# Altium schematic sheet P11_M1_RIBBON_CABLE.SchDoc(P11_M1_RIBBON_CABLE)
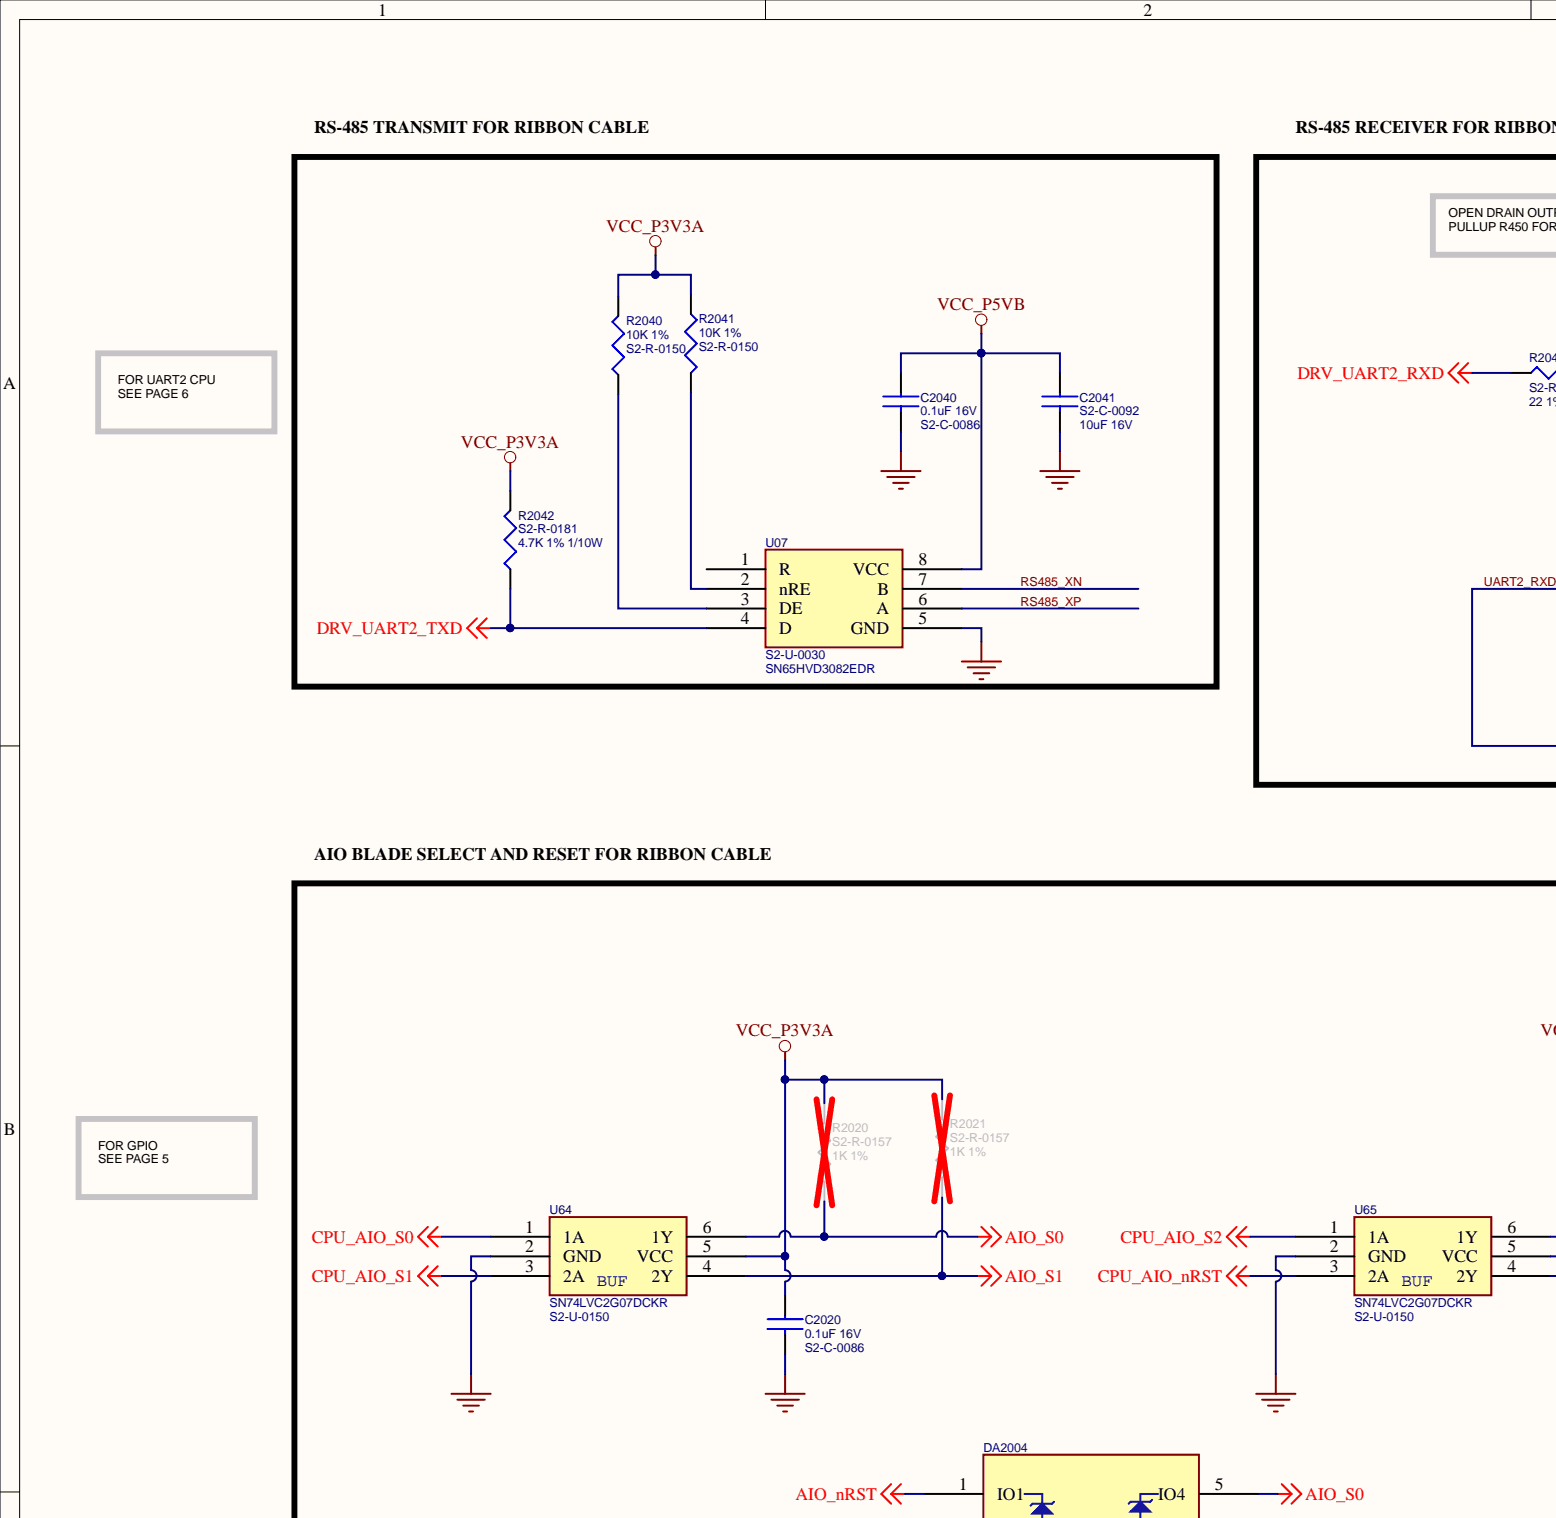
[diagram p0: P11_M1_RIBBON_CABLE.SchDoc, top left]
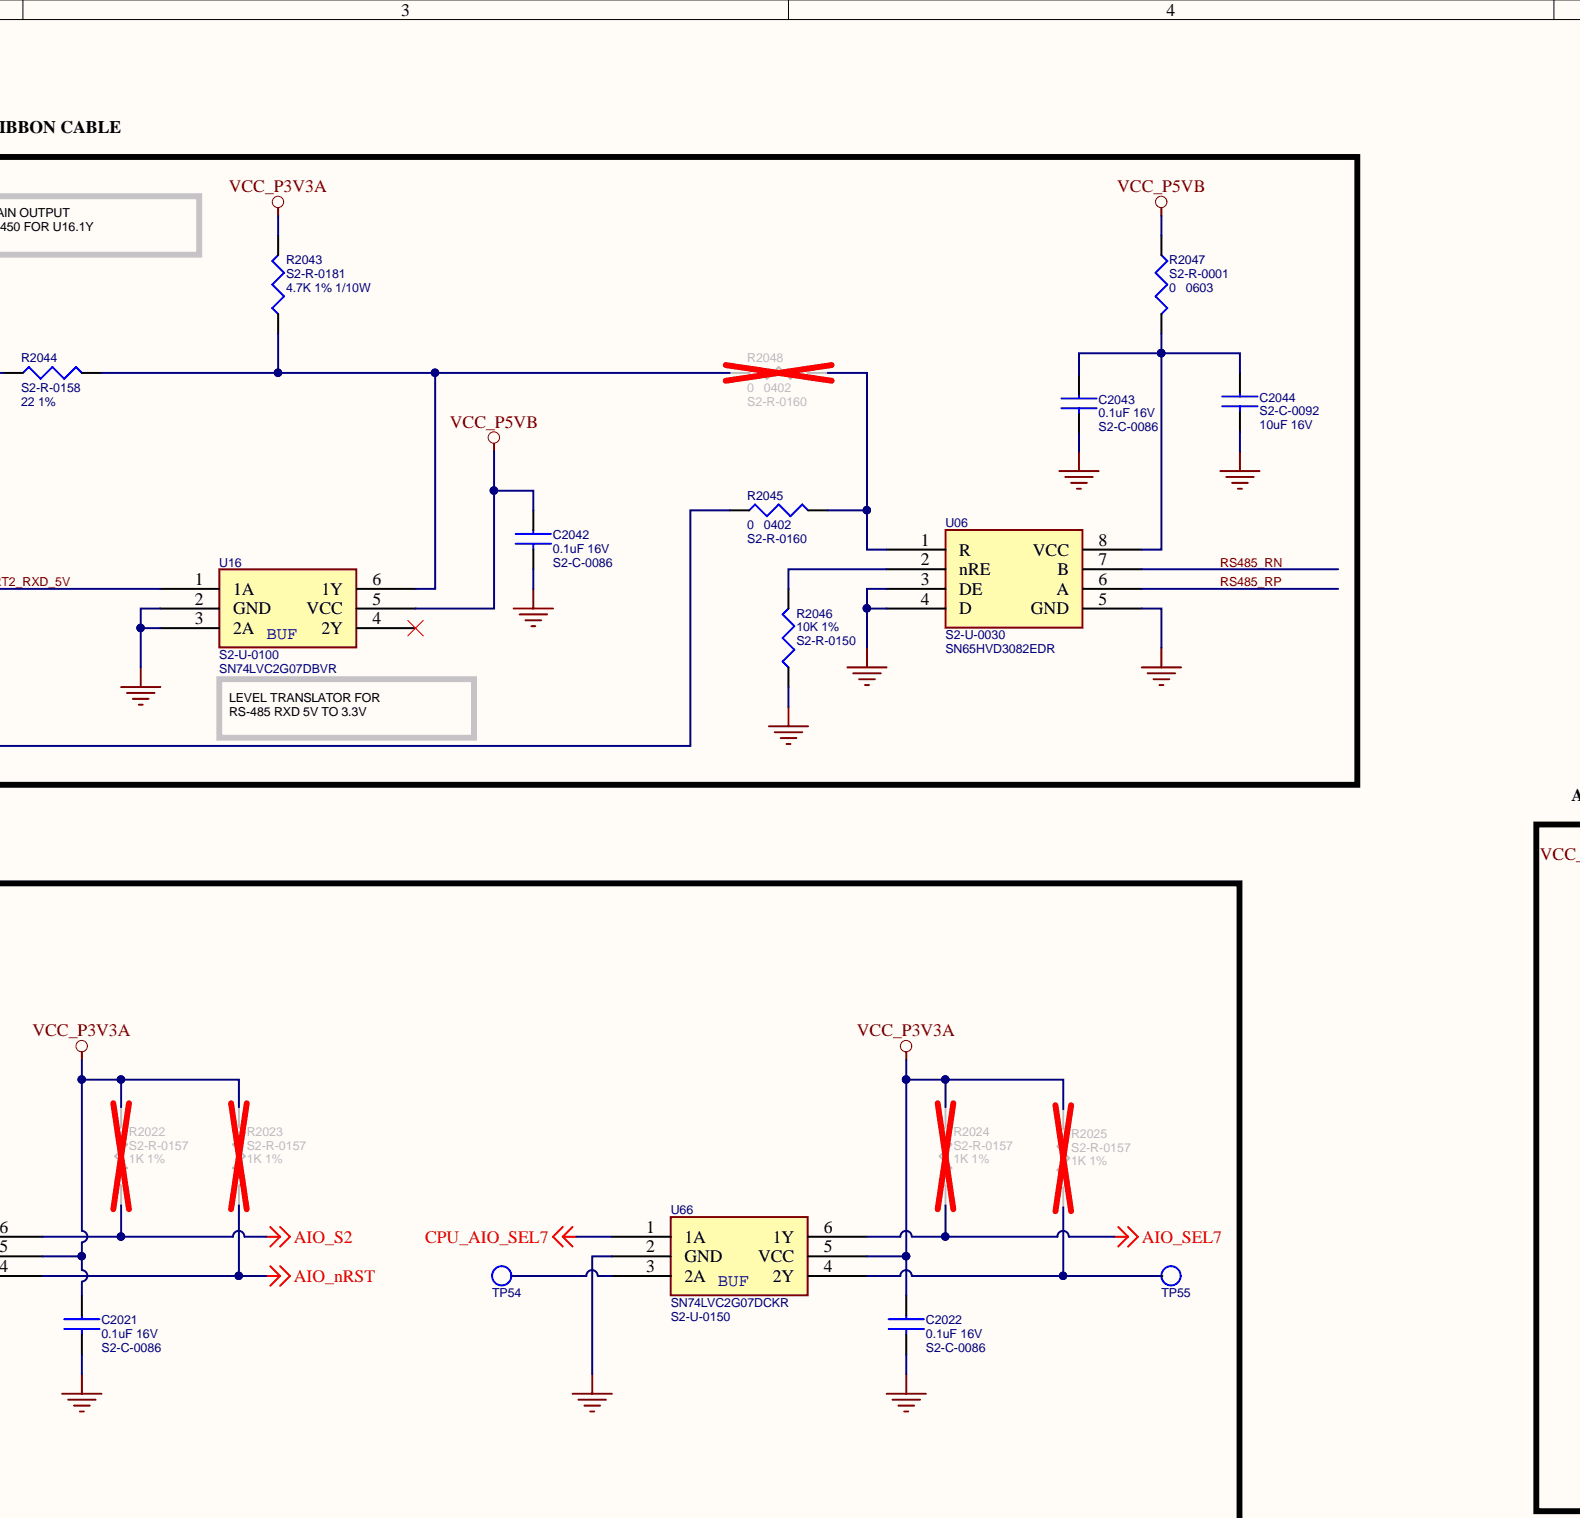
[diagram p1: P11_M1_RIBBON_CABLE.SchDoc, top centre]
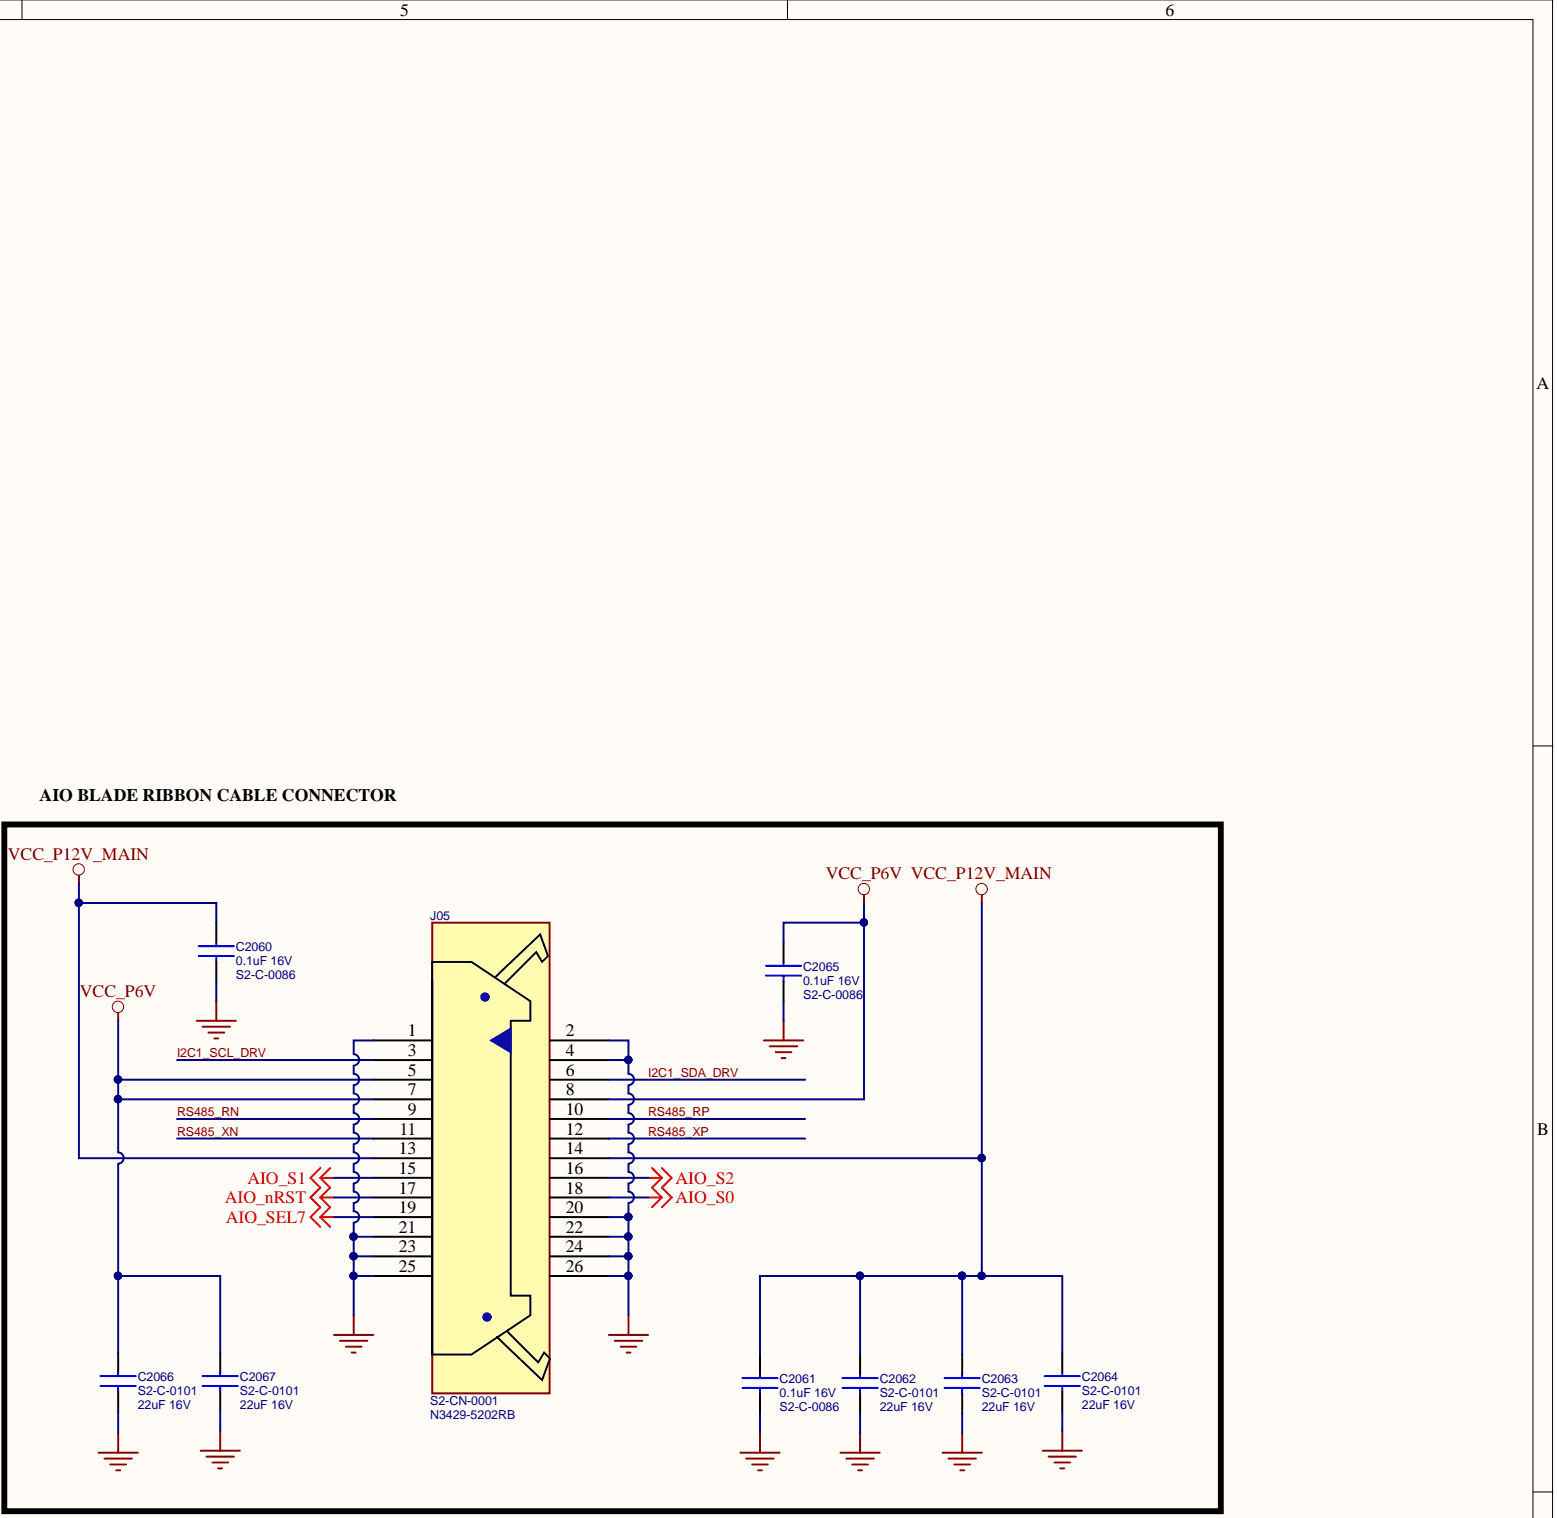
[diagram p2: P11_M1_RIBBON_CABLE.SchDoc, top right]
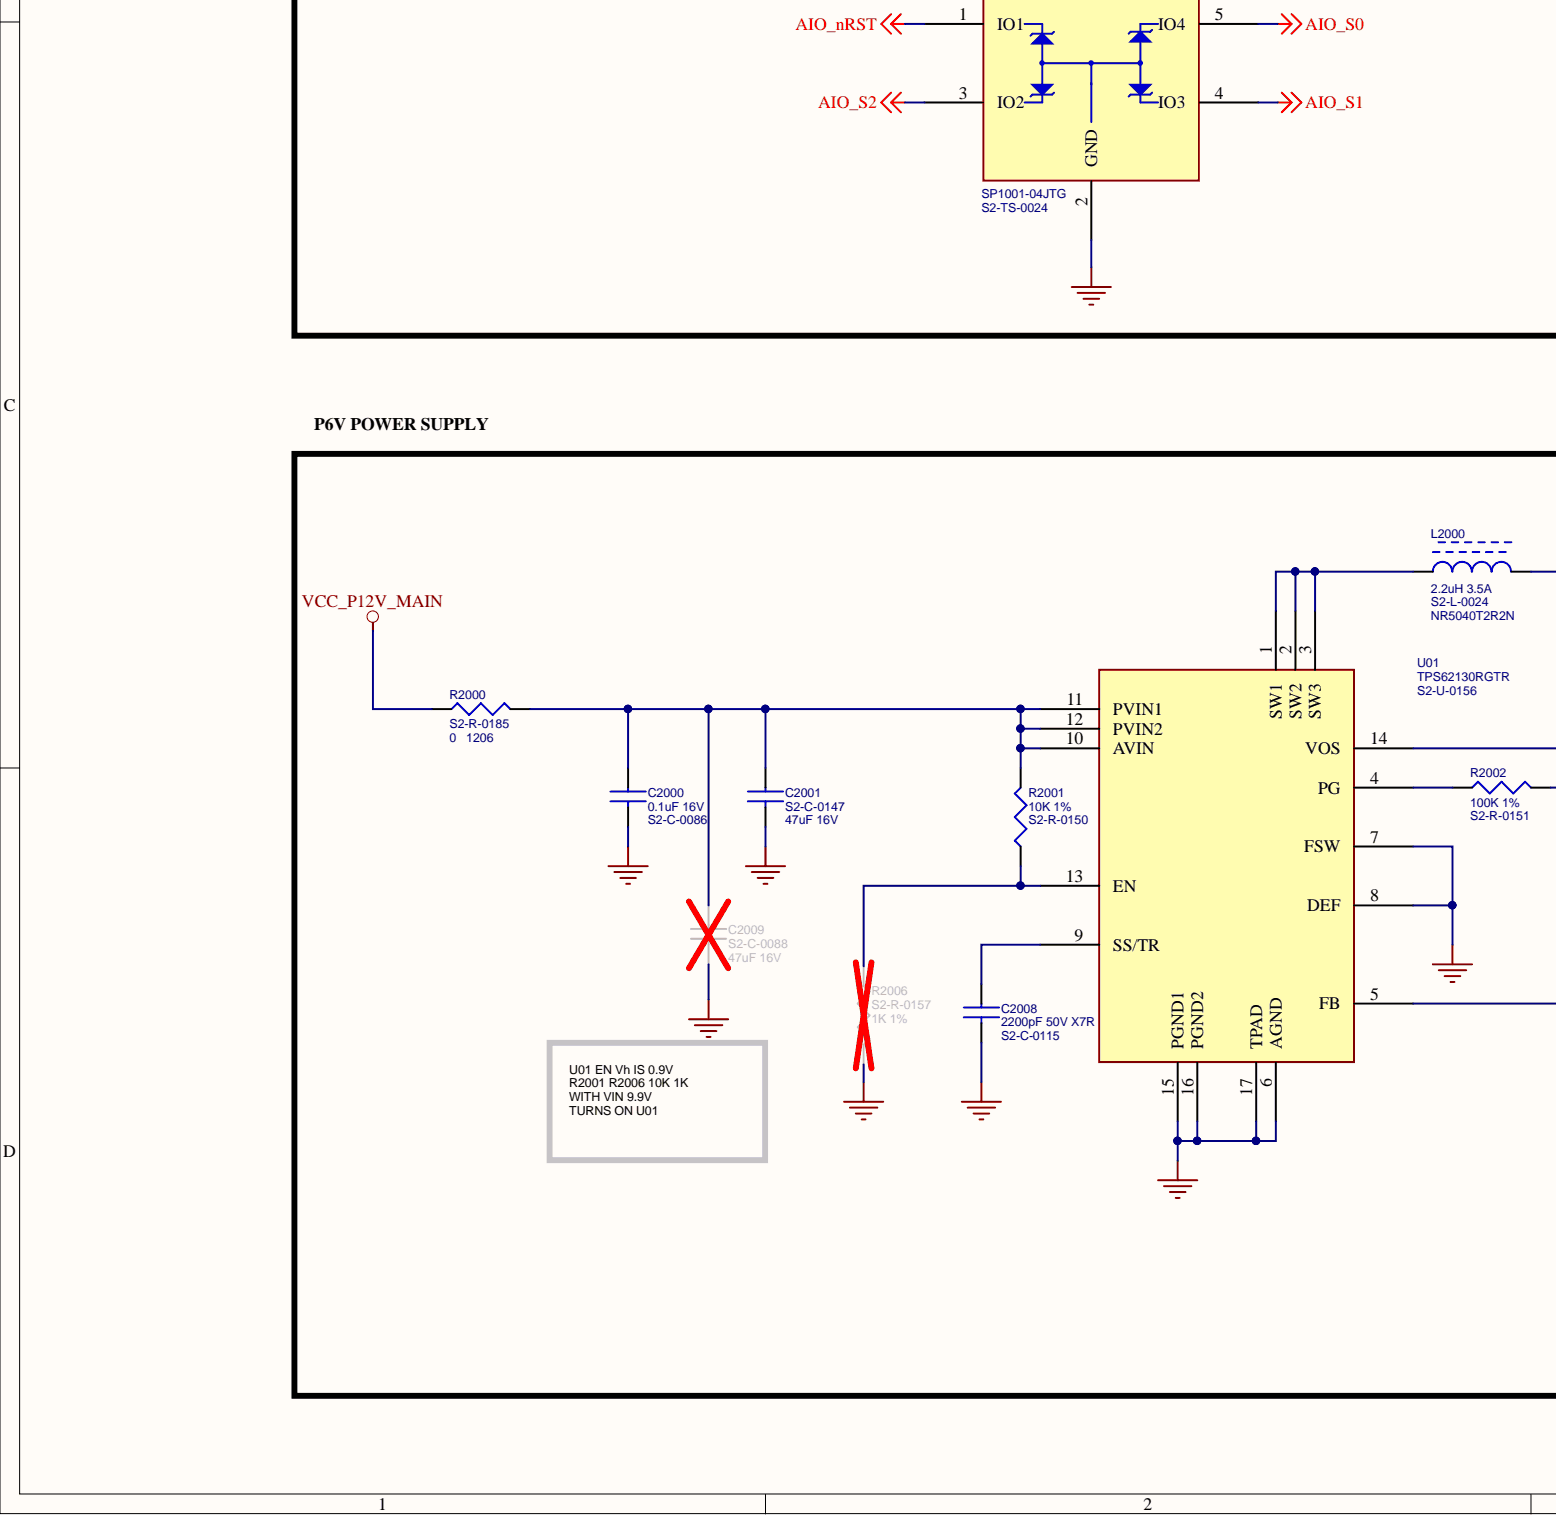
[diagram p3: P11_M1_RIBBON_CABLE.SchDoc, bottom left]
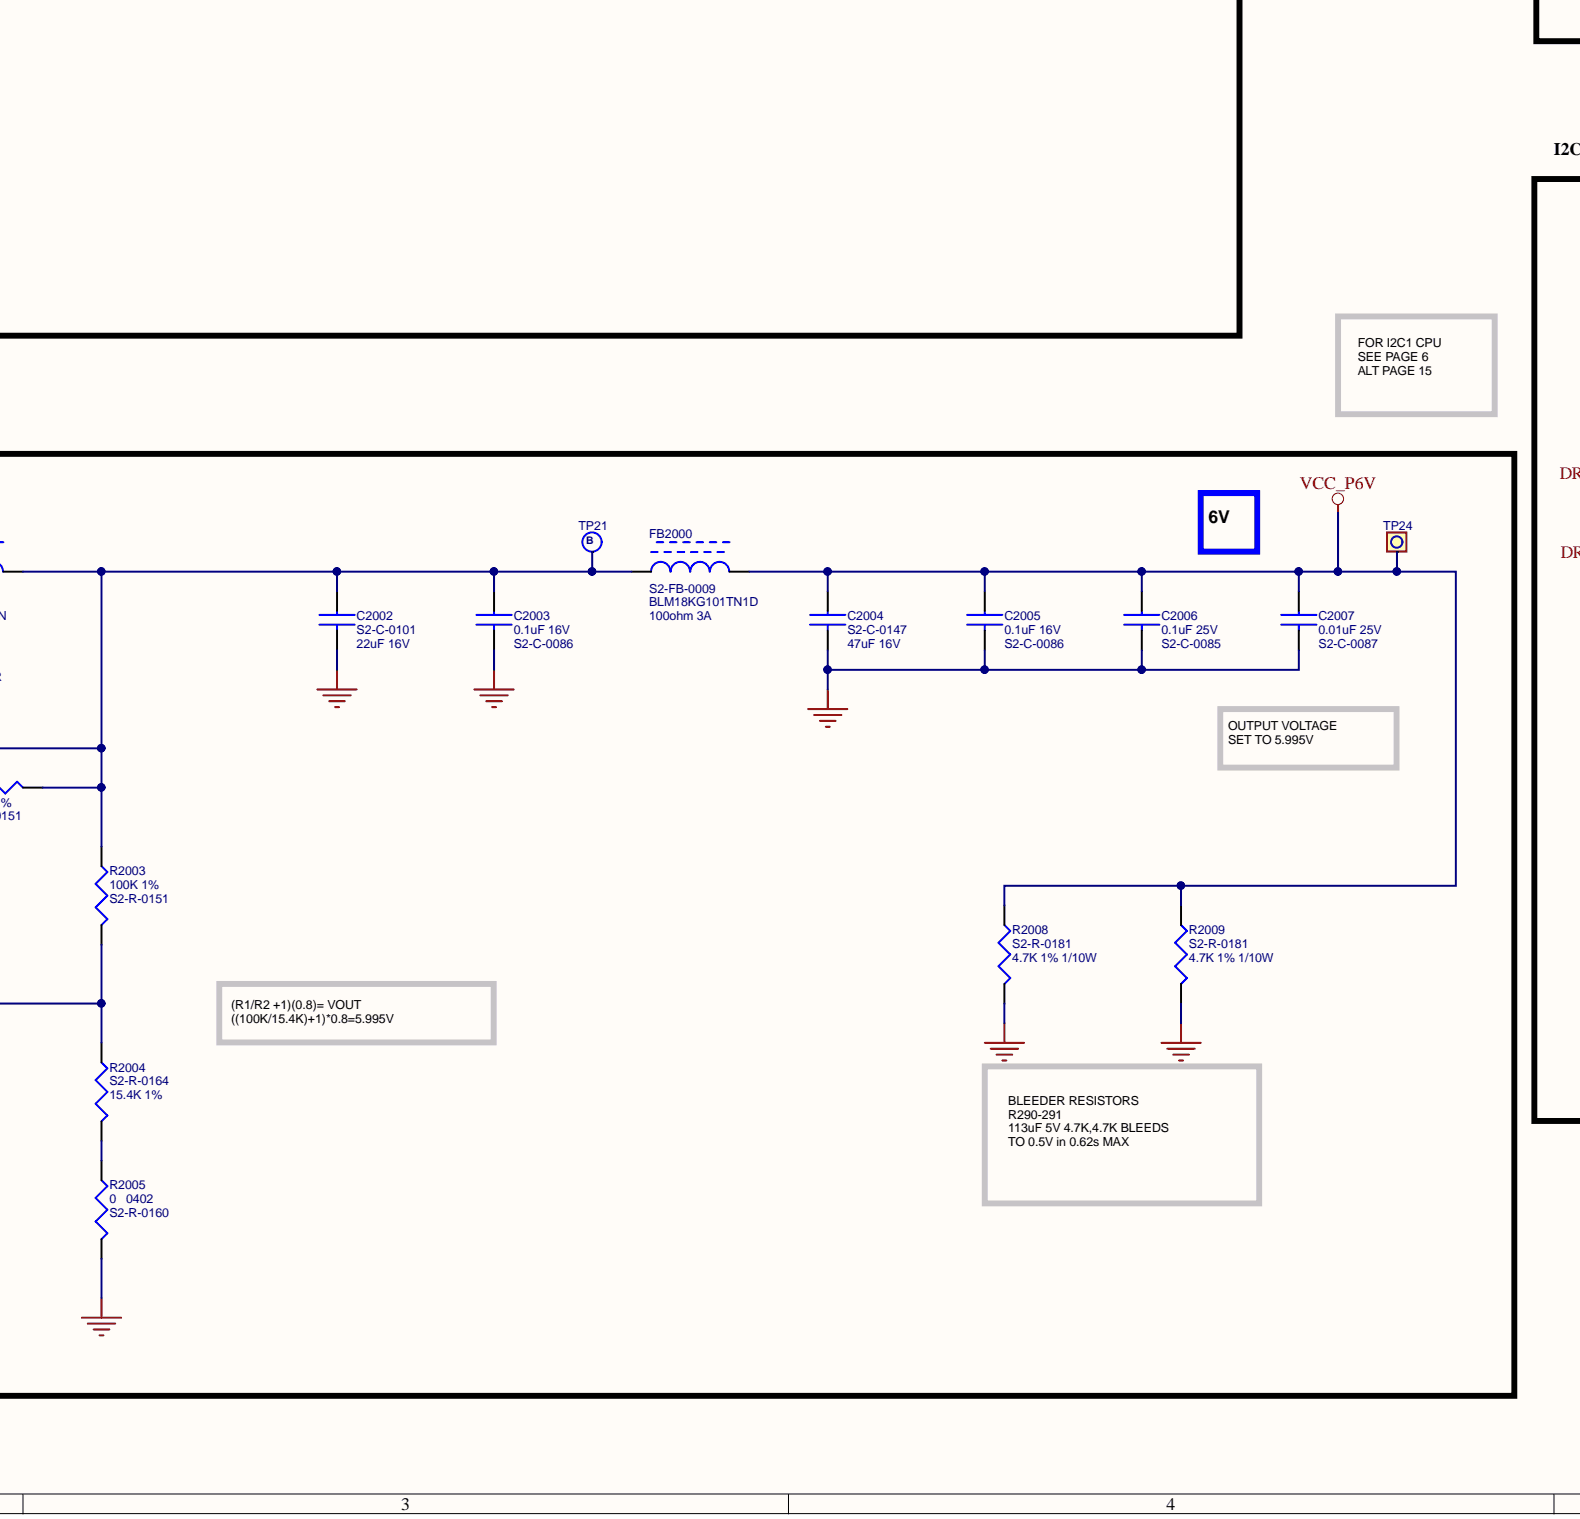
[diagram p4: P11_M1_RIBBON_CABLE.SchDoc, bottom centre]
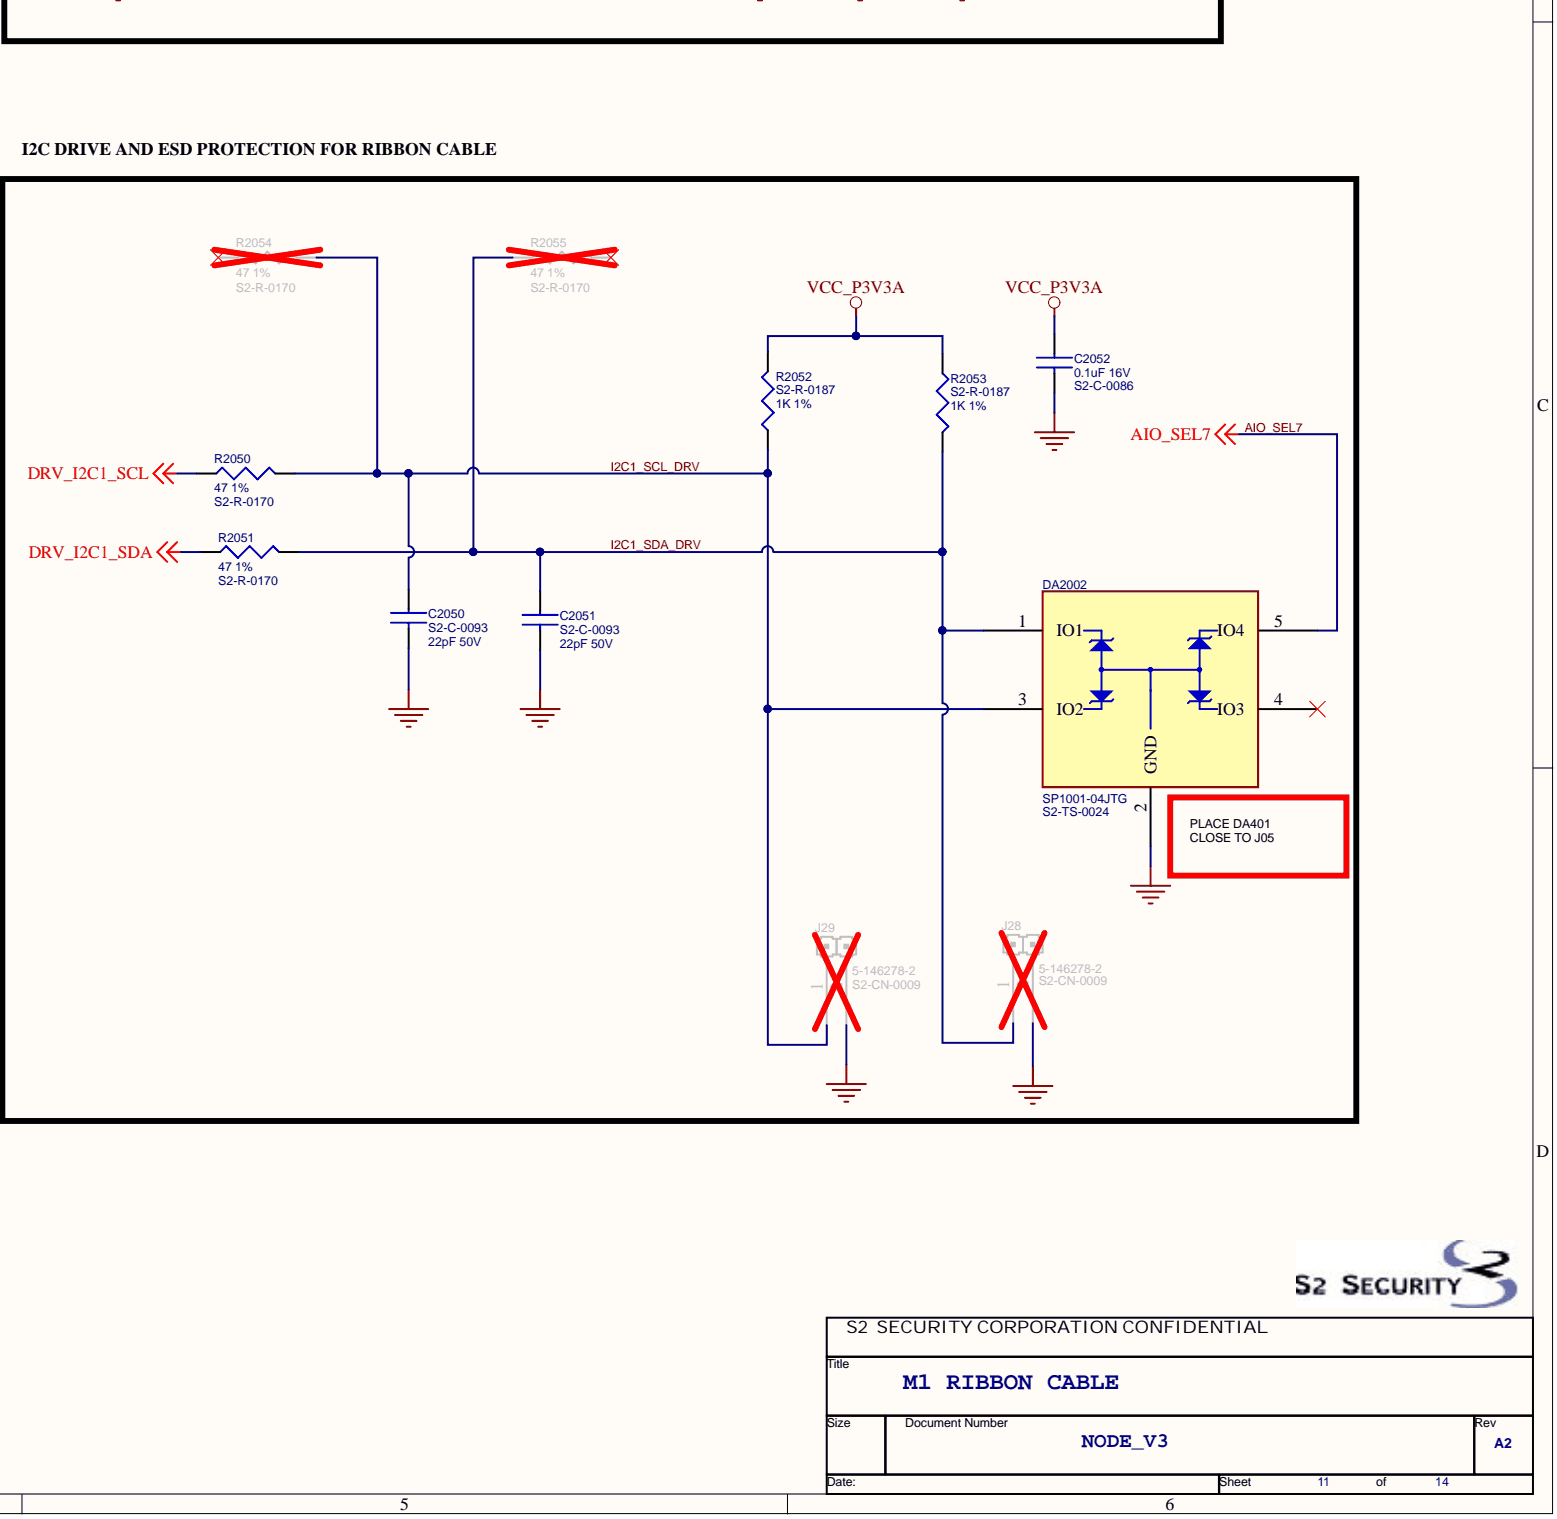
[diagram p5: P11_M1_RIBBON_CABLE.SchDoc, bottom right]

## Parts
C2000  S2_PN: S2-C-0086  DESC: 0.1uF 16V  [CAPC1005X55N]  (hidden comment: C_0U1_16V_0402)
C2001  S2_PN: S2-C-0147  DESC: 47uF 16V  [CAPC3216X180N]  (hidden comment: C_47U_16V_1206)
C2002  S2_PN: S2-C-0101  DESC: 22uF 16V  [CAPC2012X145N]  (hidden comment: C_22U_16V_0805)
C2003  S2_PN: S2-C-0086  DESC: 0.1uF 16V  [CAPC1005X55N]  (hidden comment: C_0U1_16V_0402)
C2004  S2_PN: S2-C-0147  DESC: 47uF 16V  [CAPC3216X180N]  (hidden comment: C_47U_16V_1206)
C2005  S2_PN: S2-C-0086  DESC: 0.1uF 16V  [CAPC1005X55N]  (hidden comment: C_0U1_16V_0402)
C2006  S2_PN: S2-C-0085  DESC: 0.1uF 25V  [CAPC1608X90N]  (hidden comment: C_0U1_25V_0603)
C2007  S2_PN: S2-C-0087  DESC: 0.01uF 25V  [CAPC1005X55N]  (hidden comment: C_0U01_25V_0402)
C2008  S2_PN: S2-C-0115  [CAPC1608X90N]  (hidden comment: C_2200P_50V_0603_X7R)
C2009  S2_PN: S2-C-0088  DESC: 47uF 16V  [CAPC3225X270N]  (hidden comment: C_47U_16V_1210)
C2020  S2_PN: S2-C-0086  DESC: 0.1uF 16V  [CAPC1005X55N]  (hidden comment: C_0U1_16V_0402)
C2021  S2_PN: S2-C-0086  DESC: 0.1uF 16V  [CAPC1005X55N]  (hidden comment: C_0U1_16V_0402)
C2022  S2_PN: S2-C-0086  DESC: 0.1uF 16V  [CAPC1005X55N]  (hidden comment: C_0U1_16V_0402)
C2040  S2_PN: S2-C-0086  DESC: 0.1uF 16V  [CAPC1005X55N]  (hidden comment: C_0U1_16V_0402)
C2041  S2_PN: S2-C-0092  DESC: 10uF 16V  [CAPC2012X145N]  (hidden comment: C_10U_16V_0805)
C2042  S2_PN: S2-C-0086  DESC: 0.1uF 16V  [CAPC1005X55N]  (hidden comment: C_0U1_16V_0402)
C2043  S2_PN: S2-C-0086  DESC: 0.1uF 16V  [CAPC1005X55N]  (hidden comment: C_0U1_16V_0402)
C2044  S2_PN: S2-C-0092  DESC: 10uF 16V  [CAPC2012X145N]  (hidden comment: C_10U_16V_0805)
C2050  S2_PN: S2-C-0093  DESC: 22pF 50V  [CAPC1005X55N]  (hidden comment: C_22P_50V_0402_C0G)
C2051  S2_PN: S2-C-0093  DESC: 22pF 50V  [CAPC1005X55N]  (hidden comment: C_22P_50V_0402_C0G)
C2052  S2_PN: S2-C-0086  DESC: 0.1uF 16V  [CAPC1005X55N]  (hidden comment: C_0U1_16V_0402)
C2060  S2_PN: S2-C-0086  DESC: 0.1uF 16V  [CAPC1005X55N]  (hidden comment: C_0U1_16V_0402)
C2061  S2_PN: S2-C-0086  DESC: 0.1uF 16V  [CAPC1005X55N]  (hidden comment: C_0U1_16V_0402)
C2062  S2_PN: S2-C-0101  DESC: 22uF 16V  [CAPC2012X145N]  (hidden comment: C_22U_16V_0805)
C2063  S2_PN: S2-C-0101  DESC: 22uF 16V  [CAPC2012X145N]  (hidden comment: C_22U_16V_0805)
C2064  S2_PN: S2-C-0101  DESC: 22uF 16V  [CAPC2012X145N]  (hidden comment: C_22U_16V_0805)
C2065  S2_PN: S2-C-0086  DESC: 0.1uF 16V  [CAPC1005X55N]  (hidden comment: C_0U1_16V_0402)
C2066  S2_PN: S2-C-0101  DESC: 22uF 16V  [CAPC2012X145N]  (hidden comment: C_22U_16V_0805)
C2067  S2_PN: S2-C-0101  DESC: 22uF 16V  [CAPC2012X145N]  (hidden comment: C_22U_16V_0805)
DA2002  S2_PN: S2-TS-0024  DESC: SP1001-04JTG  [SOIC65Px210X110P-5N]  (hidden comment: DA_SP1001-04JTG_ESD_QUAD)
DA2004  S2_PN: S2-TS-0024  DESC: SP1001-04JTG  [SOIC65Px210X110P-5N]  (hidden comment: DA_SP1001-04JTG_ESD_QUAD)
FB2000  S2_PN: S2-FB-0009  DESC: BLM18KG101TN1D  ATTR: 100ohm 3A  [FB0603]  (hidden comment: FB_3A_100OHM_0603_DC_30mOHM)
J05  S2_PN: S2-CN-0001  DESC: N3429-5202RB  [CONN_N3429-5202RB]  (hidden comment: CN_100_26POS_13x2_RTA_LATCH)
J28  S2_PN: S2-CN-0009  PKG: 5-146278-2  [Conn_5-146278-2]  (hidden comment: CN_100_2POS_STR_UNSHR)
J29  S2_PN: S2-CN-0009  PKG: 5-146278-2  [Conn_5-146278-2]  (hidden comment: CN_100_2POS_STR_UNSHR)
L2000  S2_PN: S2-L-0024  ATTR2: 2.2uH 3.5A  [IND_NR5040T2R2N]  (hidden comment: L_2U2_3A5_NR5040T2R2N)
R2000  S2_PN: S2-R-0185  DESC: 0   1206  [RESC3216X60N]  (hidden comment: R_0_1206)
R2001  S2_PN: S2-R-0150  DESC: 10K 1%  [RESC1005X40N]  (hidden comment: R_10K_0402)
R2002  S2_PN: S2-R-0151  DESC: 100K 1%  [RESC1005X40N]  (hidden comment: R_100K_0402)
R2003  S2_PN: S2-R-0151  DESC: 100K 1%  [RESC1005X40N]  (hidden comment: R_100K_0402)
R2004  S2_PN: S2-R-0164  DESC: 15.4K 1%  [RESC1005X40N]  (hidden comment: R_15K4_0402)
R2005  S2_PN: S2-R-0160  DESC: 0   0402  [RESC1005X40N]  (hidden comment: R_0_0402)
R2006  S2_PN: S2-R-0157  DESC: 1K 1%  [RESC1005X40N]  (hidden comment: R_1K_0402)
R2008  S2_PN: S2-R-0181  DESC: 4.7K 1% 1/10W  [RESC1608X55N]  (hidden comment: R_4K7_0603)
R2009  S2_PN: S2-R-0181  DESC: 4.7K 1% 1/10W  [RESC1608X55N]  (hidden comment: R_4K7_0603)
R2020  S2_PN: S2-R-0157  DESC: 1K 1%  [RESC1005X40N]  (hidden comment: R_1K_0402)
R2021  S2_PN: S2-R-0157  DESC: 1K 1%  [RESC1005X40N]  (hidden comment: R_1K_0402)
R2022  S2_PN: S2-R-0157  DESC: 1K 1%  [RESC1005X40N]  (hidden comment: R_1K_0402)
R2023  S2_PN: S2-R-0157  DESC: 1K 1%  [RESC1005X40N]  (hidden comment: R_1K_0402)
R2024  S2_PN: S2-R-0157  DESC: 1K 1%  [RESC1005X40N]  (hidden comment: R_1K_0402)
R2025  S2_PN: S2-R-0157  DESC: 1K 1%  [RESC1005X40N]  (hidden comment: R_1K_0402)
R2040  S2_PN: S2-R-0150  DESC: 10K 1%  [RESC1005X40N]  (hidden comment: R_10K_0402)
R2041  S2_PN: S2-R-0150  DESC: 10K 1%  [RESC1005X40N]  (hidden comment: R_10K_0402)
R2042  S2_PN: S2-R-0181  DESC: 4.7K 1% 1/10W  [RESC1608X55N]  (hidden comment: R_4K7_0603)
R2043  S2_PN: S2-R-0181  DESC: 4.7K 1% 1/10W  [RESC1608X55N]  (hidden comment: R_4K7_0603)
R2044  S2_PN: S2-R-0158  DESC: 22 1%  [RESC1005X40N]  (hidden comment: R_22_0402)
R2045  S2_PN: S2-R-0160  DESC: 0   0402  [RESC1005X40N]  (hidden comment: R_0_0402)
R2046  S2_PN: S2-R-0150  DESC: 10K 1%  [RESC1005X40N]  (hidden comment: R_10K_0402)
R2047  S2_PN: S2-R-0001  DESC: 0   0603  [RESC1608X55N]  (hidden comment: R_0_0603)
R2048  S2_PN: S2-R-0160  DESC: 0   0402  [RESC1005X40N]  (hidden comment: R_0_0402)
R2050  S2_PN: S2-R-0170  DESC: 47 1%  [RESC1005X40N]  (hidden comment: R_47_0402)
R2051  S2_PN: S2-R-0170  DESC: 47 1%  [RESC1005X40N]  (hidden comment: R_47_0402)
R2052  S2_PN: S2-R-0187  DESC: 1K 1%  [RESC1608X55N]  (hidden comment: R_1K_0603)
R2053  S2_PN: S2-R-0187  DESC: 1K 1%  [RESC1608X55N]  (hidden comment: R_1K_0603)
R2054  S2_PN: S2-R-0170  DESC: 47 1%  [RESC1005X40N]  (hidden comment: R_47_0402)
R2055  S2_PN: S2-R-0170  DESC: 47 1%  [RESC1005X40N]  (hidden comment: R_47_0402)
TP21  TP_SMT_TEST_POINT_BOTTOM  [TP35_SMT]  (value not drawn)
TP24  TP_TH_TEST_POINT_SQUARE  [TEST-PT-TH-SQ]  (value not drawn)
TP54  TP_TH_TEST_POINT  [TEST-PT-TH]  (value not drawn)
TP55  TP_TH_TEST_POINT  [TEST-PT-TH]  (value not drawn)
U01  S2_PN: S2-U-0156  DESC: TPS62130RGTR  [QFN050PX310x100-16N]  (hidden comment: U_TPS62130RGTR_BUCK_17V_3A)
U06  S2_PN: S2-U-0030  DESC: SN65HVD3082EDR  [SOIC127P600X175-8N]  (hidden comment: U_RS485_HALF_DUPLEX)
U07  S2_PN: S2-U-0030  DESC: SN65HVD3082EDR  [SOIC127P600X175-8N]  (hidden comment: U_RS485_HALF_DUPLEX)
U16  S2_PN: S2-U-0100  DESC: SN74LVC2G07DBVR  [SOT95P280X145-6N]  (hidden comment: U_SN74LVC2G07DBVR_DUAL_BUFFER)
U64  S2_PN: S2-U-0150  DESC: SN74LVC2G07DCKR  [SOIC65Px210X110P-6N]  (hidden comment: U_SN74LVC2G07DCKR_DUAL_BUFFER)
U65  S2_PN: S2-U-0150  DESC: SN74LVC2G07DCKR  [SOIC65Px210X110P-6N]  (hidden comment: U_SN74LVC2G07DCKR_DUAL_BUFFER)
U66  S2_PN: S2-U-0150  DESC: SN74LVC2G07DCKR  [SOIC65Px210X110P-6N]  (hidden comment: U_SN74LVC2G07DCKR_DUAL_BUFFER)

## Nets
AIO_nRST: DA2004.1(IO1) J05.17 R2023.2 U65.4(2Y)
AIO_S0: DA2004.5(IO4) J05.18 R2020.2 U64.6(1Y)
AIO_S1: DA2004.4(IO3) J05.15 R2021.2 U64.4(2Y)
AIO_S2: DA2004.3(IO2) J05.16 R2022.2 U65.6(1Y)
CPU_AIO_nRST: U65.3(2A)
CPU_AIO_S0: U64.1(1A)
CPU_AIO_S1: U64.3(2A)
CPU_AIO_S2: U65.1(1A)
CPU_AIO_SEL7: U66.1(1A)
DRV_I2C1_SCL: R2050.1
DRV_I2C1_SDA: R2051.1
DRV_UART2_RXD: R2044.1
DRV_UART2_TXD: R2042.2 U07.4(D)
GND: C2000.2 C2001.2 C2002.2 C2003.2 C2004.2 C2005.2 C2006.2 C2007.2 C2008.2 C2009.2 C2020.2 C2021.2 C2022.2 C2040.2 C2041.2 C2042.2 C2043.2 C2044.2 C2050.2 C2051.2 C2052.2 C2060.2 C2061.2 C2062.2 C2063.2 C2064.2 C2065.2 C2066.2 C2067.2 DA2002.2(GND) DA2004.2(GND) J05.1 J05.2 J05.4 J05.20 J05.21 J05.22 J05.23 J05.24 J05.25 J05.26 J28.2 J29.2 R2005.2 R2006.2 R2008.2 R2009.2 R2046.2 U01.6(AGND) U01.7(FSW) U01.8(DEF) U01.15(PGND1) U01.16(PGND2) U01.17(TPAD) U06.3(DE) U06.4(D) U06.5(GND) U07.5(GND) U16.2(GND) U16.3(2A) U64.2(GND) U65.2(GND) U66.2(GND)
VCC_P3V3A: C2020.1 C2021.1 C2022.1 C2052.1 R2020.1 R2021.1 R2022.1 R2023.1 R2024.1 R2025.1 R2040.1 R2041.1 R2042.1 R2043.1 R2052.1 R2053.1 U64.5(VCC) U65.5(VCC) U66.5(VCC)
VCC_P5VB: C2040.1 C2041.1 C2042.1 R2047.1 U07.8(VCC) U16.5(VCC)
VCC_P6V: C2004.1 C2005.1 C2006.1 C2007.1 C2065.1 C2066.1 C2067.1 FB2000.2 J05.5 J05.7 J05.8 R2008.1 R2009.1 TP24.1
VCC_P12V_MAIN: C2060.1 C2061.1 C2062.1 C2063.1 C2064.1 J05.13 J05.14 R2000.1
AIO_SEL7: DA2002.5(IO4) J05.19 R2024.2 U66.6(1Y)
I2C1_SCL_DRV: C2050.1 DA2002.3(IO2) J05.3 J29.1 R2050.2 R2052.2 R2054.2
I2C1_SDA_DRV: C2051.1 DA2002.1(IO1) J05.6 J28.1 R2051.2 R2053.2 R2055.1
RS485_RN: J05.9 U06.7(B)
RS485_RP: J05.10 U06.6(A)
RS485_XN: J05.11 U07.7(B)
RS485_XP: J05.12 U07.6(A)
UART2_RXD_5V: R2045.1 U16.1(1A)
NetC2000_1: C2000.1 C2001.1 C2009.1 R2000.2 R2001.1 U01.10(AVIN) U01.11(PVIN1) U01.12(PVIN2)
NetC2002_1: C2002.1 C2003.1 FB2000.1 L2000.2 R2002.2 R2003.1 TP21.1 U01.14(VOS)
NetC2008_1: C2008.1 U01.9(SS/TR)
NetC2043_1: C2043.1 C2044.1 R2047.2 U06.8(VCC)
NetL2000_1: L2000.1 U01.1(SW1) U01.2(SW2) U01.3(SW3)
NetR2001_2: R2001.2 R2006.1 U01.13(EN)
NetR2002_1: R2002.1 U01.4(PG)
NetR2003_2: R2003.2 R2004.1 U01.5(FB)
NetR2004_2: R2004.2 R2005.1
NetR2025_2: R2025.2 TP55.1 U66.4(2Y)
NetR2040_2: R2040.2 U07.3(DE)
NetR2041_2: R2041.2 U07.2(nRE)
NetR2043_2: R2043.2 R2044.2 R2048.1 U16.6(1Y)
NetR2045_2: R2045.2 R2048.2 U06.1(R)
NetR2046_1: R2046.1 U06.2(nRE)
NetTP54_1: TP54.1 U66.3(2A)

Not connected: DA2002 4(IO3); R2054 1; R2055 2; U07 1(R); U16 4(2Y)

## Library data of the parts
C2000 C2003 C2005 C2020-C2022 C2040 C2042 C2043 C2052 C2060 C2061 C2065 = C_0U1_16V_0402: PKG: CC0402IPC; ATTR: X7R 10%; Library Name: NODE_V2_M1.SCHLIB; Component Kind: Standard
C2001 C2004 = C_47U_16V_1206: PKG: CC1206IPC; ATTR: X5R 20%; Library Name: NODE_V2_M1.SCHLIB; Component Kind: Standard
C2002 C2062-C2064 C2066 C2067 = C_22U_16V_0805: PKG: CC0805IPC; ATTR: X5R 20%; Library Name: NODE_V2_M1.SCHLIB; Component Kind: Standard
C2006 = C_0U1_25V_0603: PKG: CC0603IPC; ATTR: X7R 10%; Library Name: NODE_V2_M1.SCHLIB; Component Kind: Standard
C2007 = C_0U01_25V_0402: PKG: CC0402IPC; ATTR: X7R 10%; Library Name: NODE_V2_M1.SCHLIB; Component Kind: Standard
C2008 = C_2200P_50V_0603_X7R: PKG: CC0603IPC; DESC: 2200pF 50V X7R; ATTR: X7R 10%; Library Name: NODE_V2_M1.SCHLIB; Component Kind: Standard
C2009 = C_47U_16V_1210: PKG: CC1210IPC; ATTR: X5R 20%; Library Name: NODE_V2_M1.SCHLIB; Component Kind: Standard
C2041 C2044 = C_10U_16V_0805: PKG: CC0805IPC; ATTR: X5R 10%; Library Name: NODE_V2_M1.SCHLIB; Component Kind: Standard
C2050 C2051 = C_22P_50V_0402_C0G: PKG: CC0402IPC; ATTR: C0G 5%; Library Name: NODE_V2_M1.SCHLIB; Component Kind: Standard
DA2002 DA2004 = DA_SP1001-04JTG_ESD_QUAD: PKG: SC-70-5; ATTR: TVS, UNI_DIR SP1001, 4 Channel, 8pF, 15kV  Array; Library Name: NODE_V2_M1.SCHLIB; Component Kind: Standard
FB2000 = FB_3A_100OHM_0603_DC_30mOHM: PKG: CC0603IPC; Library Name: NODE_V2_M1.SCHLIB; Component Kind: Standard
J05 = CN_100_26POS_13x2_RTA_LATCH: PKG: 2M54_13x2_TH_LATCH; ATTR: CONN HEADER LATCH/EJEC  RTA DUAL ROW 26POS; Library Name: NODE_V2_M1.SCHLIB; Component Kind: Standard
J28 J29 = CN_100_2POS_STR_UNSHR: ATTR: HEADER MALE 2POS 0.100" STRAIGHT UNSHROUDED; Library Name: NODE_V2_M1.SCHLIB; DESC: 5-146278-2; Component Kind: Standard
L2000 = L_2U2_3A5_NR5040T2R2N: PKG: NR5040; ATTR: INDUCTOR 2.2uH 30% 0.029ohm 3.5A; Library Name: NODE_V2_M1.SCHLIB; DESC: NR5040T2R2N; Component Kind: Standard
R2000 = R_0_1206: PKG: CC1206IPC; ATTR: RES 0 OHM 1/4W 0.020MAX 1206 3.5A; Library Name: NODE_V2_M1.SCHLIB; Component Kind: Standard
R2001 R2040 R2041 R2046 = R_10K_0402: PKG: CC0402IPC; ATTR: 1/16W; Library Name: NODE_V2_M1.SCHLIB; Component Kind: Standard
R2002 R2003 = R_100K_0402: PKG: CC0402IPC; ATTR: 1/16W; Library Name: NODE_V2_M1.SCHLIB; Component Kind: Standard
R2004 = R_15K4_0402: PKG: CC0402IPC; ATTR: 1/16W; Library Name: NODE_V2_M1.SCHLIB; Component Kind: Standard
R2005 R2045 R2048 = R_0_0402: PKG: CC0402IPC; ATTR: 1/16W  50mohm MAX; Library Name: NODE_V2_M1.SCHLIB; Component Kind: Standard
R2006 R2020-R2025 = R_1K_0402: PKG: CC0402IPC; ATTR: 1/16W; Library Name: NODE_V2_M1.SCHLIB; Component Kind: Standard
R2008 R2009 R2042 R2043 = R_4K7_0603: PKG: CC0603IPC; ATTR: RES 4.7K OHM 1/10W 1% 0603; Library Name: NODE_V2_M1.SCHLIB; Component Kind: Standard
R2044 = R_22_0402: PKG: CC0402IPC; ATTR: 1/16W; Library Name: NODE_V2_M1.SCHLIB; Component Kind: Standard
R2047 = R_0_0603: PKG: CC0603IPC; ATTR: 1/10W 50mohm MAX; Library Name: NODE_V2_M1.SCHLIB; Component Kind: Standard
R2050 R2051 R2054 R2055 = R_47_0402: PKG: CC0402IPC; ATTR: 1/16W; Library Name: NODE_V2_M1.SCHLIB; Component Kind: Standard
R2052 R2053 = R_1K_0603: PKG: 0603; ATTR: RES 1K OHM 1/10W 1% 0603; Library Name: NODE_V2_M1.SCHLIB; Component Kind: Standard
TP21 = TP_SMT_TEST_POINT_BOTTOM: S2_PN: S2-TP-0003; PKG: TEST-PT-TPB; DESC: TEST POINT; ATTR: SMT BOTTOM SIDE TEST POINT; Library Name: NODE_V2_M1.SCHLIB; DNP: DNP; Component Kind: Standard
TP24 = TP_TH_TEST_POINT_SQUARE: S2_PN: S2-TP-0004; PKG: TEST-PT-TH; DESC: TEST POINT; ATTR: THRU HOLE TEST POINT; Library Name: NODE_V2_M1.SCHLIB; DNP: DNP; Component Kind: Standard
TP54 TP55 = TP_TH_TEST_POINT: S2_PN: S2-TP-0001; DESC: TEST POINT; ATTR: THRU HOLE TEST POINT; PKG: TEST-PT-TH; Library Name: NODE_V2_M1.SCHLIB; DNP: DNP; Component Kind: Standard
U01 = U_TPS62130RGTR_BUCK_17V_3A: PKG: QFN-16_3X3_6215X; ATTR: IC REG STEP-DOWN CONV 3A  3V-17V; Library Name: NODE_V2_M1.SCHLIB; Component Kind: Standard
U06 U07 = U_RS485_HALF_DUPLEX: PKG: SOIC-8; ATTR: IC LO-PWR RS-485 TXRX HALF DUPLEX TRIPLE FAILSAFE; Library Name: NODE_V2_M1.SCHLIB; Component Kind: Standard
U16 = U_SN74LVC2G07DBVR_DUAL_BUFFER: PKG: SOT-23-6-DBV; ATTR: IC 74LVC2G07 BUFFER DUAL OPEN DRAIN 1.65 -5.5V OPERATION; Library Name: NODE_V2_M1.SCHLIB; Component Kind: Standard
U64-U66 = U_SN74LVC2G07DCKR_DUAL_BUFFER: PKG: SOT-363; ATTR: IC 74LVC2G07 BUFFER DUAL OPEN DRAIN 1.65V-5.5V OPERATION; Library Name: NODE_V2_M1.SCHLIB; Component Kind: Standard
